# Altium schematic sheet cpu.SchDoc(cpu)
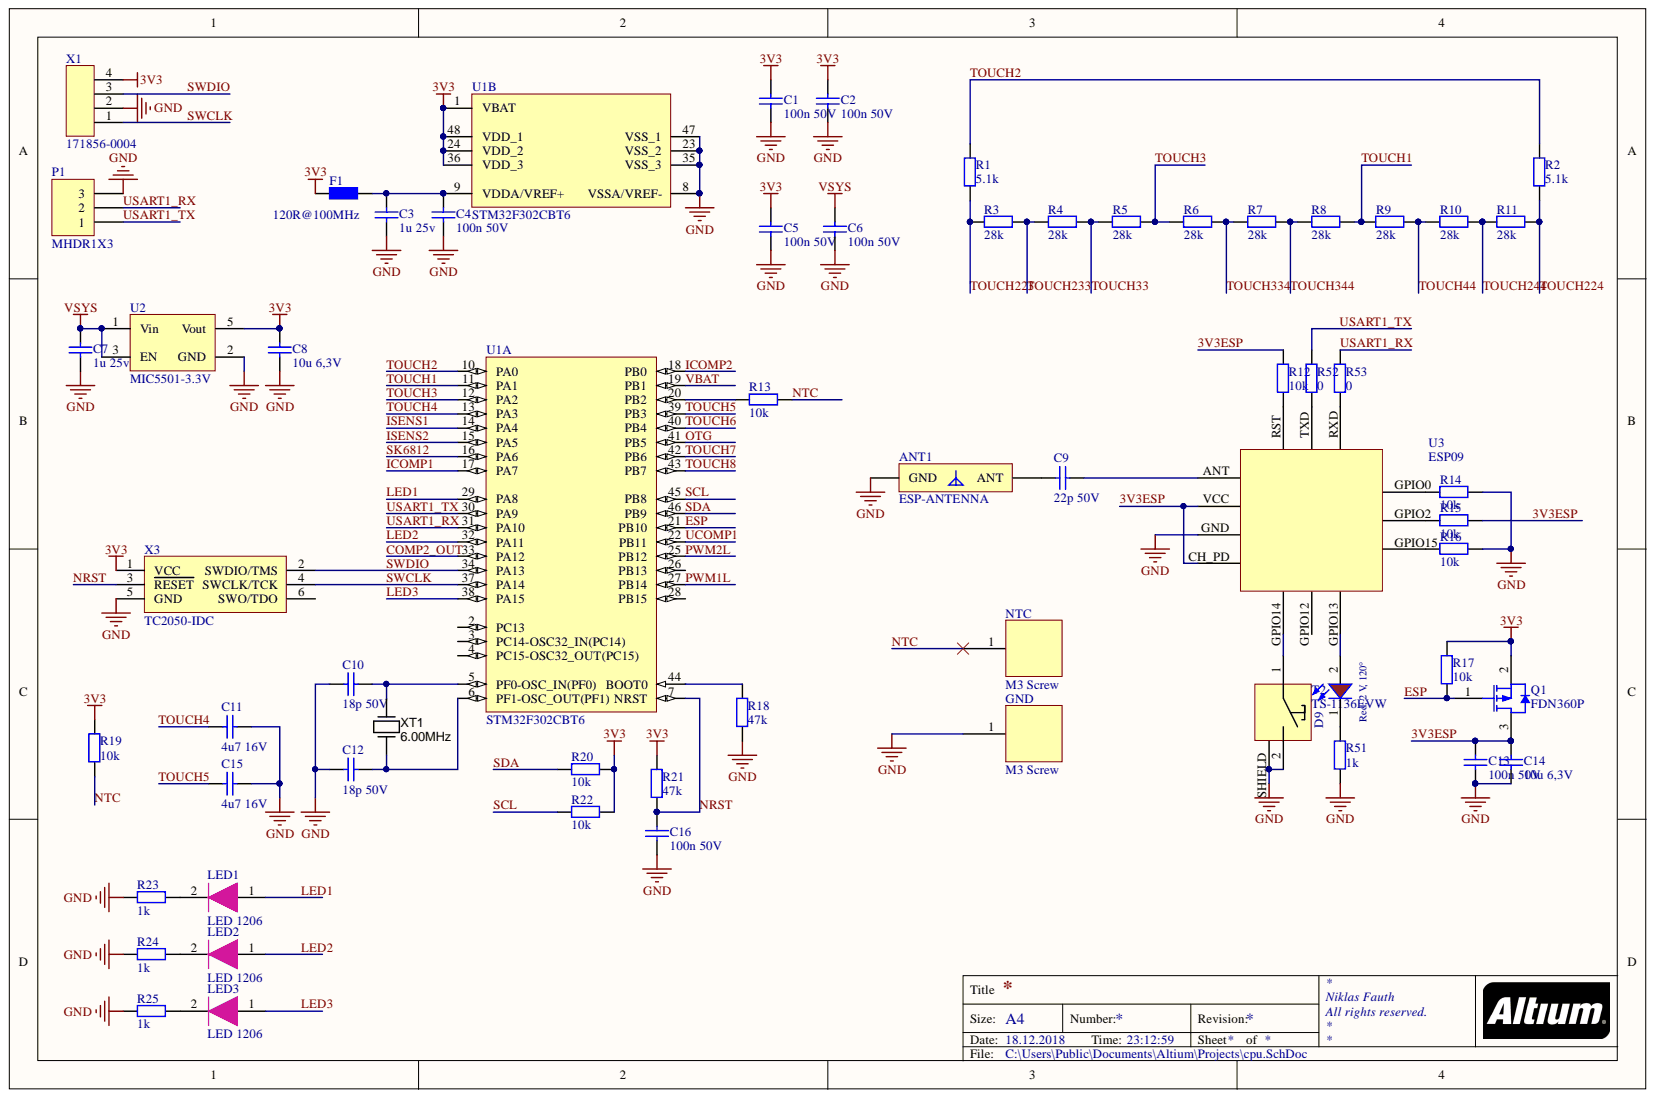
[diagram p0: cpu.SchDoc]

## Parts
ANT1  ESP-ANTENNA  [ESP8266_ANT]
C1  100n 50V  [C-0603-H]
C2  100n 50V  [C-0603-H]
C3  1u 25v  [C-0603-H]
C4  100n 50V  [C-0603-H]
C5  100n 50V  [C-0603-H]
C6  100n 50V  [C-0603-H]
C7  1u 25v  [C-0603-H]
C8  10u 6,3V  [C-0603-H]
C9  22p 50V  [C-0603-H]
C10  18p 50V  [C-0402-L]
C11  4u7 16V  [C-0603-H]
C12  18p 50V  [C-0402-L]
C13  100n 50V  [C-0603-H]
C14  10u 6,3V  [C-0603-H]
C15  4u7 16V  [C-0603-H]
C16  100n 50V  [C-0603-H]
D9  Red, 2 V, 120°  Viewing Angle: 120°  [WL-SMSW_1204]
F1  120R@100MHz  [R-0805-H]
GND  M3 Screw  [M3 Screw]
LED1  LED 1206  [PCBComponent_1]
LED2  LED 1206  [PCBComponent_1]
LED3  LED 1206  [PCBComponent_1]
NTC  M3 Screw  [M3 Screw]
P1  MHDR1X3  [MHDR1X3]
Q1  FDN360P  [ONSC-SOT-23-3-527AG-01_L]
R1  5.1k  [R-0603-H]
R2  5.1k  [R-0603-H]
R3  28k  [R-0603-M]
R4  28k  [R-0603-M]
R5  28k  [R-0603-M]
R6  28k  [R-0603-M]
R7  28k  [R-0603-M]
R8  28k  [R-0603-M]
R9  28k  [R-0603-M]
R10  28k  [R-0603-M]
R11  28k  [R-0603-M]
R12  10k  [R-0603-H]
R13  10k  [R-0603-H]
R14  10k  [R-0603-H]
R15  10k  [R-0603-H]
R16  10k  [R-0603-H]
R17  10k  [R-0603-H]
R18  47k  [R-0603-H]
R19  10k  [R-0603-H]
R20  10k  [R-0603-H]
R21  47k  [R-0603-H]
R22  10k  [R-0603-H]
R23  1k  [R-0603-M]
R24  1k  [R-0603-M]
R25  1k  [R-0603-M]
R51  1k  [R-0603-M]
R52  0  [R-0603-M]
R53  0  [R-0603-M]
T2  TS-1136EVW  [TS-1136EVW]
U1  STM32F302CBT6  [STM-LQFP48_L]  (parts A,B)
U2  MIC5501-3.3V  [SOT23-5]
U3  ESP09  [ESP09]
X1  171856-0004  [PINHEAD-MALE-4]
X3  TC2050-IDC  [TC2030-IDC-NL]
XT1  6.00MHz  [CRYSTAL_HC49-US-SM]

## Nets
3V3: C1.2 C2.2 C5.2 C8.2 F1.1 Q1.2 R17.1 R19.1 R20.2 R21.2 R22.2 U1.1(VBAT) U1.24(VDD_2) U1.36(VDD_3) U1.48(VDD_1) U2.5(Vout) X1.4 X3.1(VCC)
GND: ANT1.2 C1.1 C2.1 C3.1 C4.1 C5.1 C6.1 C7.1 C8.1 C10.2 C11.2 C12.2 C13.1 C14.1 C15.2 C16.1 GND.1 P1.3 R14.2 R16.2 R18.1 R23.2 R24.2 R25.2 R51.2 T2.2 T2.SHIELD U1.8(VSSA/VREF-) U1.23(VSS_2) U1.35(VSS_3) U1.47(VSS_1) U2.2(GND) U3.GND X1.2 X3.5(GND)
VSYS: C6.2 C7.2 U2.1(Vin) U2.3(EN)
3V3ESP: C13.2 C14.2 Q1.3 R12.2 R15.2 U3.CH_PD U3.VCC
COMP2_OUT: U1.33(PA12)
ESP: Q1.1 R17.2 U1.21(PB10)
ICOMP1: U1.17(PA7)
ICOMP2: U1.18(PB0)
ISENS1: U1.14(PA4)
ISENS2: U1.15(PA5)
LED1: LED1.1 U1.29(PA8)
LED2: LED2.1 U1.32(PA11)
LED3: LED3.1 U1.38(PA15)
NRST: C16.2 R21.1 U1.7(NRST) X3.3(RESET)
NTC: NTC.1 R13.2 R19.2
OTG: U1.41(PB5)
PWM1L: U1.27(PB14)
PWM2L: U1.25(PB12)
SCL: R22.1 U1.45(PB8)
SDA: R20.1 U1.46(PB9)
SK6812: U1.16(PA6)
SWCLK: U1.37(PA14) X1.1 X3.4(SWCLK/TCK)
SWDIO: U1.34(PA13) X1.3 X3.2(SWDIO/TMS)
TOUCH1: R8.2 R9.1 U1.11(PA1)
TOUCH2: R1.2 R2.2 U1.10(PA0)
TOUCH3: R5.2 R6.1 U1.12(PA2)
TOUCH4: C11.1 U1.13(PA3)
TOUCH5: C15.1 U1.39(PB3)
TOUCH6: U1.40(PB4)
TOUCH7: U1.42(PB6)
TOUCH8: U1.43(PB7)
TOUCH33: R4.2 R5.1
TOUCH44: R9.2 R10.1
TOUCH223: R1.1 R3.1
TOUCH224: R2.1 R11.2
TOUCH233: R3.2 R4.1
TOUCH244: R10.2 R11.1
TOUCH334: R6.2 R7.1
TOUCH344: R7.2 R8.1
UCOMP1: U1.22(PB11)
USART1_RX: P1.2 R53.2 U1.31(PA10)
USART1_TX: P1.1 R52.2 U1.30(PA9)
VBAT: U1.19(PB1)
NetANT1_1: ANT1.1 C9.1
NetC3_2: C3.2 C4.2 F1.2 U1.9(VDDA/VREF+)
NetC9_2: C9.2 U3.ANT
NetC10_1: C10.1 U1.5(PF0-OSC_IN(PF0)) XT1.2
NetC12_1: C12.1 U1.6(PF1-OSC_OUT(PF1)) XT1.1
NetD9_1: D9.1 R51.1
NetD9_2: D9.2 U3.GPIO13
NetLED1_2: LED1.2 R23.1
NetLED2_2: LED2.2 R24.1
NetLED3_2: LED3.2 R25.1
NetR12_1: R12.1 U3.RST
NetR13_1: R13.1 U1.20(PB2)
NetR14_1: R14.1 U3.GPIO0
NetR15_1: R15.1 U3.GPIO2
NetR16_1: R16.1 U3.GPIO15
NetR18_2: R18.2 U1.44(BOOT0)
NetR52_1: R52.1 U3.TXD
NetR53_1: R53.1 U3.RXD
NetT2_1: T2.1 U3.GPIO14

Not connected: U1 2(PC13) 3(PC14-OSC32_IN(PC14)) 4(PC15-OSC32_OUT(PC15)) 26(PB13) 28(PB15); U3 GPIO12; X3 6(SWO/TDO)

## Library data of the parts
ANT1 = ESP-ANT: Library Name: advanced.IntLib; Component Kind: Standard
C1 C2 C4-C6 C13 C16 = C-0603-100n-50V: Capacitor 100n 50V; Supplier Part Number 1: 80-C0603C104K5RAUTO; Supplier 1: Mouser; Library Name: Passives.IntLib; Component Kind: Standard
C3 C7 = C-0603-1u-25V: Capacitor 1uF 25V; Supplier Part Number 1: 80-C0603C105K3R; Supplier 1: Mouser; Library Name: Passives.IntLib; Component Kind: Standard
C8 C14 = C-0603-10u-6,3V: Capacitor 10uF 6,3V; Supplier Part Number 1: 80-C0603C106M9P; Supplier 1: Mouser; Library Name: Passives.IntLib; Component Kind: Standard
C9 = C-0603-22p-50V: Capacitor 22p 50V; Supplier Part Number 1: 80-C0603C220J5G; Supplier 1: Mouser; Library Name: Capacitors-0603.SchLib; Component Kind: Standard
C10 C12 = C-0402-18p-50V: Capacitor 18p 50V; Supplier Part Number 1: 80-C0402C180J5G; Supplier 1: Mouser; Library Name: Passives.IntLib; Component Kind: Standard
C11 C15 = C-0603-4u7-16V: Capacitor 4.7uF 16V; Supplier Part Number 1: 81-GRM188R61C475KAJD; Supplier 1: Mouser; Library Name: Capacitors-0603.SchLib; Component Kind: Standard
D9 = 155124RS73200: WL-SMSW SMD Mono-color Side view Waterclear, size 1204, Red, 2 V, 120°; Peak Wavelength: 632 nm; Luminous Intensity: 130 mcd; Forward Voltage: 2 V; Dominant Wavelength: 624 nm; Size: 1204; Published Date: May 2018; Part Number: 155124RS73200; Operating Temperature: -40 to 85 degC; Mounting Technology: SMT; Library Name: ALTIUM-WL-SMSW-rev18b.IntLib; Emitting Color: Red; ComponentLink2URL: http://katalog.we-online.de/led/datasheet/155124RS73200.pdf; ComponentLink2Description: Datasheet; ComponentLink1URL: http://www.we-online.de; ComponentLink1Description: Manufacturer; Component Kind: Standard; Publisher: Wurth Elektronik
F1 = F-0805-120R@100mhz: Ferrite 120R@100MHz; Supplier Part Number 1: 81-DLW21HN121SQ2L; Supplier 1: Mouser; Library Name: Passives.IntLib; Component Kind: Standard
GND NTC = M3: Library Name: M3.SchLib; Component Kind: Standard
P1 = MHDR1X3: Header, 3-Pin; Signal Integrity: Connector; Library Name: Miscellaneous Connectors.IntLib; LatestRevisionNote: Re-released for DXP Platform.; LatestRevisionDate: 17-Jul-2002; Component Kind: Standard; Publisher: Altium Limited
Q1 = CMP-2000-07455-1: Single P-Channel PowerTrench(R) MOSFET, 30 V, 2 A, -55 to 150 degC, 3-Pin SOT23, RoHS, Tape and Reel; Manufacturer: Fairchild; Voltage Rating (DC): -30V; Turn-On Delay Time: 6ns; Turn-Off Delay Time: 11ns; Threshold Voltage: 20V; Rise Time: 13ns; Rds On Max: 80mOhm; Power Dissipation: 500mW; Package Version: Rev. O, 12/2008; Number of Elements: 1; Input Capacitance: 298pF; Drain to Source Voltage (Vdss): 30V; Drain to Source Resistance: 63mOhm; Current Rating: -2A; Continuous Drain Current (ID): 2A; Case\/Package: SOT-23; Width: 1.4mm; Weight: 0.03g; RoHSCompliant: true; REACH SVHC: NoSVHC; Radiation Hardening: No; Packaging: TapeandReel; Package URL: http://www.onsemi.com/pub_link/Collateral/527AG.PDF; Package Reference: SOT-23-3-527AG-01; Package Quantity: 1; Package Description: 3-Pin Small Outline Transistor, Body 2.92 x 1.3 mm, Pitch 0.95 mm; Number of Pins: 3; Mounting Technology: SurfaceMount; Mount: SurfaceMount; Min Operating Temperature: -55degC; Max Power Dissipation: 500mW; Max Operating Temperature: 150degC; Manufacturer URL: https://www.fairchildsemi.com/; Library Name: Altium Content Vault; Length: 2.92mm; Lead Free: LeadFree; Height: 0.94mm; Gate to Source Voltage (Vgs): 20V; Fall Time: 6ns; Element Configuration: Single; Dual Supply Voltage: -30V; Drain to Source Breakdown Voltage: -30V; Datasheet Version: Rev. F1(W), 05/2003; Datasheet URL: http://www.onsemi.cn/pub/Collateral/FDN360PCN-D.pdf; Contact Plating: Tin; Component Kind: Standard
R1 R2 = R-0603-5k1: Resistor 5.1k; Supplier Part Number 1: 71-CRCW0603-5.1K-E3; Supplier 1: Mouser; Library Name: Resistors-0603.SchLib; Component Kind: Standard
R3-R11 = R-0603-28k: Resistor 28k; Supplier Part Number 1: 71-CRCW0603-28K-E3; Supplier 1: Mouser; Library Name: Resistors-0603.SchLib; Component Kind: Standard
R12-R17 R19 R20 R22 = R-0603-10k: Resistor 10k; Supplier Part Number 1: 71-CRCW0603-10K-E3; Supplier 1: Mouser; Library Name: Resistors-0603.SchLib; Component Kind: Standard
R18 R21 = R-0603-47k: Resistor 4k7; Supplier Part Number 1: 71-CRCW060347K0FKEB; Supplier 1: Mouser; Library Name: Passives.IntLib; Component Kind: Standard
R23-R25 R51 = R-0603-1k: Resistor 1k; Supplier Part Number 1: 71-CRCW0603-1.0K-E3; Supplier 1: Mouser; Library Name: Passives.IntLib; Component Kind: Standard
R52 R53 = R-0603-0: Resistor 0; Supplier Part Number 1: 71-CRCW0603-0-E3; Supplier 1: Mouser; Resistance: 0; Library Name: Resistors-0603.SchLib; Component Kind: Standard
U1 = CMP-0572-00016-2: ARM Cortex-M4 32-bit MCU, 128 KB Flash, 24 KB Internal RAM, 37 I/Os, 48-pin LQFP, -40 to 85 degC, Tray; Supply Voltage(Vcc) min (V): 2; Operating Frequency(F) (Processor speed) (MHz): 72; Internal RAM Size (kB): 24; I/Os (High Current): 37; FLASH Size (Prog) (kB): 128; D/A Converter: 1x12-bit (1 Channel); PartNumber: STM32F302CBT6; Packing: Tray; PackageVersion: Rev. 6, 06/2013; PackageReference: LQFP48; PackageDescription: 48-Pin Low Profile Quad Flat Package, 7 x 7 mm Body, 0.5 mm Pitch; Mounting Technology: Surface Mount; Library Name: Altium Content Vault; DatasheetVersion: Rev. 6; Core: ARM Cortex-M4; ComponentLink3URL: http://www.st.com/st-web-ui/static/active/en/resource/technical/document/datasheet/DM00058181.pdf; ComponentLink3Description: Package Specification; ComponentLink2URL: http://www.st.com/st-web-ui/static/active/en/resource/technical/document/datasheet/DM00058181.pdf; ComponentLink2Description: Datasheet; ComponentLink1URL: http://www.st.com/web/en/home.html; ComponentLink1Description: Manufacturer URL; Component Kind: Standard
U3 = ESP09: ESP09 Module; Library Name: ESP09.SchLib; Component Kind: Standard
X1 = PINHEAD-MALE-4: Library Name: advanced.SchLib; Component Kind: Standard
X3 = TAG-Connect-SWD: TC2050-IDC SWD; Library Name: advanced.SchLib; Component Kind: Standard
XT1 = Crystal_6MHz_HC49/US: Resonator Ceramic 6.00 MHz 30ppm; Manufacturer Part Number 1: ATS060BSM-1; Manufacturer 1: CTS-Frequency Controls; SCDType: spec; Parameter Name: 0.169" (4.30mm); Operating Mode: Fundamental; Load Capacitance: 18pF; Frequency Stability: ±50ppm; Frequency: 6MHz; Type: MHz Crystal; Supplier Part Number 1: CTX882CT-ND; Supplier 1: Digi-Key; Size / Dimension: 0.437" L x 0.190" W (11.10mm x 4.83mm); Ratings: 6.00 MHz; Package / Case: HC49/US-SM; Operating Temperature: -20°C ~ 70°C; Mounting Type: Surface Mount; Library Name: PBL - Resonators.SCHLIB; Frequency Tolerance: ±30ppm; ComponentLink1URL: http://www.ctscorp.com/components/Datasheets/008-0309-0.pdf; ComponentLink1Description: http://www.ctscorp.com/components/Datasheets/008-0309-0.pdf; Component Kind: Standard
LED1-LED3 = LED 1206: Library Name: LED1206.SchLib; Description: LED 1206; Component Kind: Standard
T2 = TS-1136EVW: Description: TS-1136EVW; Component Kind: Standard; Library Name: Taster.SchLib
U2 = MIC5501-3.3V: Supplier Part Number 1: 998-MIC5501-3.0YM5TR; Supplier 1: Mouser; Library Name: advanced.IntLib; Component Kind: Standard
